# Altium schematic sheet SENSOR-NPC(1210-20).SchDoc(SENSOR-NPC(1210-20))
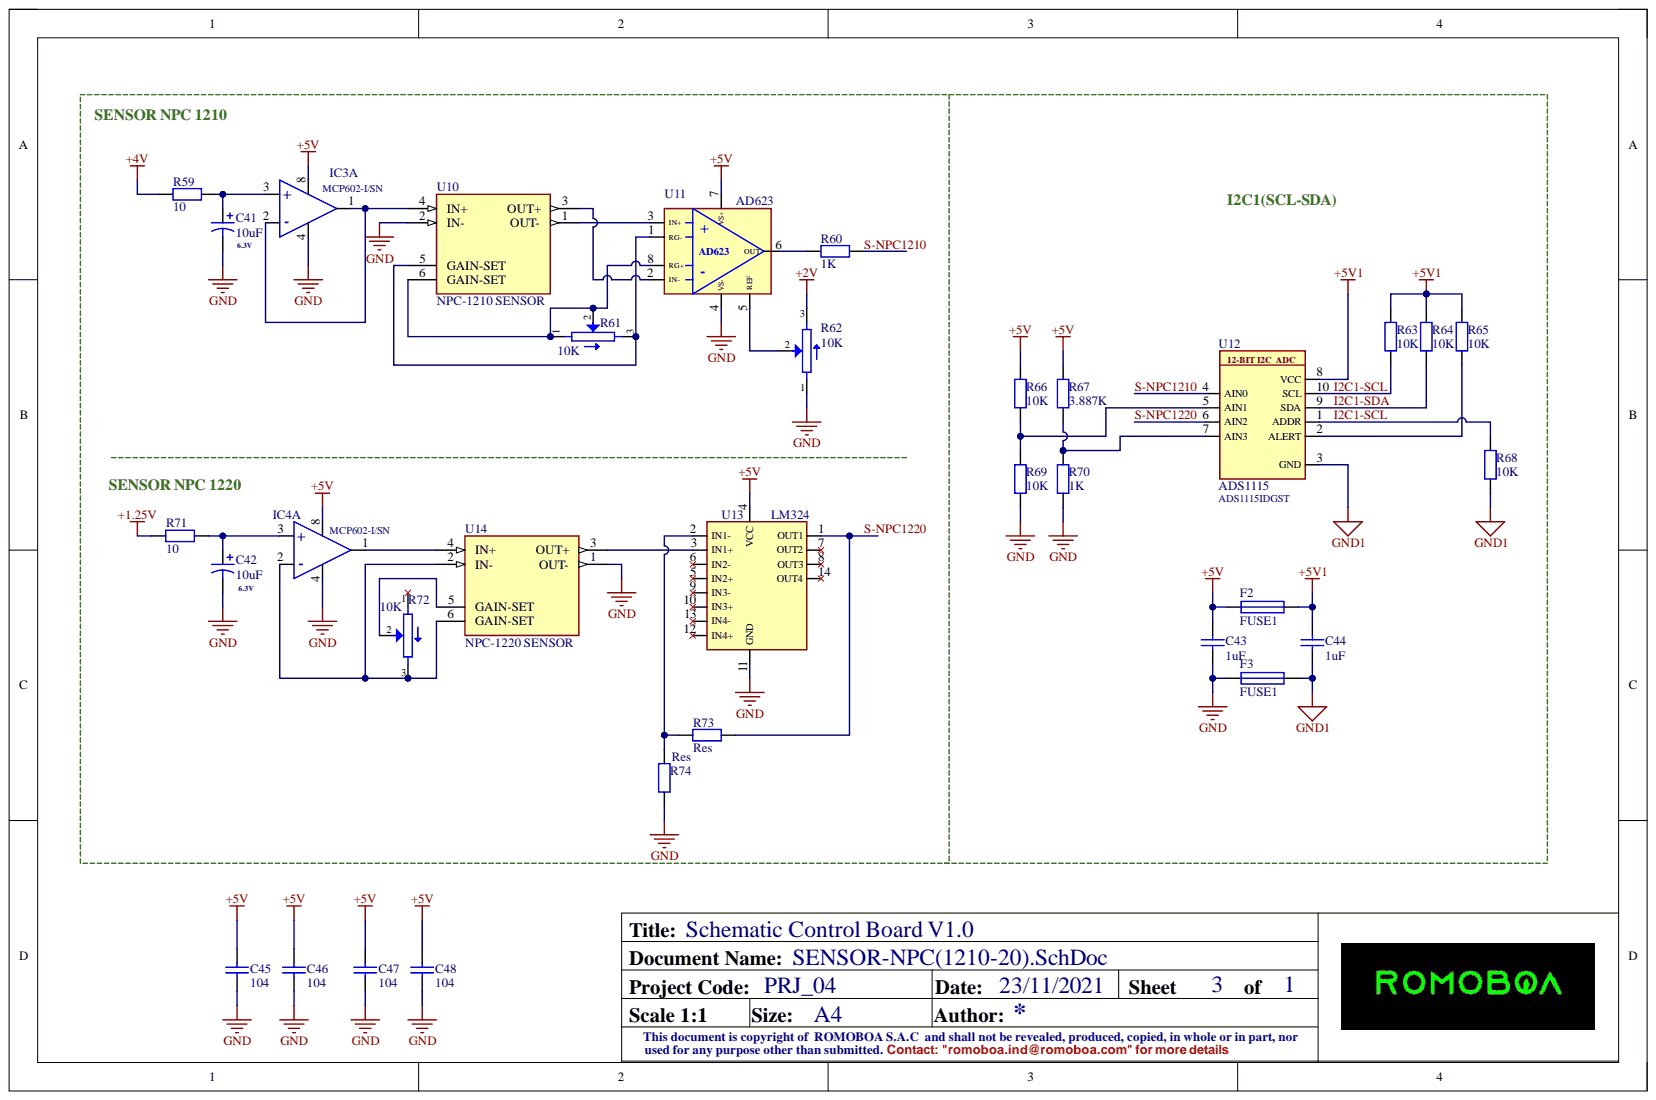
[diagram p0: SENSOR-NPC]

## Parts
C41  10uF  [CAPAE-D5.0x5.4]
C42  10uF  [CAPAE-D5.0x5.4]
C43  1uF  [CAP0805]
C44  1uF  [CAP0805]
C45  104  [CAP0805]
C46  104  [CAP0805]
C47  104  [CAP0805]
C48  104  [CAP0805]
F2  FUSE1  [FUSE: 0805]
F3  FUSE1  [FUSE: 0805]
IC3  Manufacturer Part Number: MCP602-I/SN  [MAX485 :SOIC-8]  (hidden comment: MCP602SN)  (part A)
IC4  Manufacturer Part Number: MCP602-I/SN  [MAX485 :SOIC-8]  (hidden comment: MCP602SN)  (part A)
R59  10  [RES: 0805R]
R60  1K  [RES: 0805R]
R61  10K  [POT: RES: 3296W2]
R62  10K  [POT: RES: 3296W2]
R63  10K  [RES: 0805R]
R64  10K  [RES: 0805R]
R65  10K  [RES: 0805R]
R66  10K  [RES: 0805R]
R67  3.887K  [RES: 0805R]
R68  10K  [RES: 0805R]
R69  10K  [RES: 0805R]
R70  1K  [RES: 0805R]
R71  10  [RES: 0805R]
R72  10K  [POT: RES: 3296W2]
R73  Res  [RES: 0805R]
R74  Res  [RES: 0805R]
U10  NPC-1210 SENSOR  [XDCR_NPC-1210-100G-3-S]
U11  AD623  [MAX485 :SOIC-8]
U12  ADS1115  Interface: I2C  Manufacturer Part Number: ADS1115IDGST  [CH340E: 10-MSOP]
U13  LM324  [SOIC-14]
U14  NPC-1220 SENSOR  [XDCR_NPC-1210-100G-3-S]

## Nets
+1.25V: R71.2
+2V: R62.3
+4V: R59.1
+5V: C43.2 C45.2 C46.2 C47.2 C48.2 F2.1 IC3.8 IC4.8 R66.2 R67.2 U11.7(VS+) U13.4(VCC)
+5V1: C44.2 F2.2 R63.1 R64.1 R65.1 U12.8(VCC)
GND: C41.2 C42.2 C43.1 C45.1 C46.1 C47.1 C48.1 F3.1 IC3.4 IC4.4 R62.1 R69.1 R70.1 R74.2 U10.2(IN-) U11.4(VS-) U13.11(GND) U14.1(OUT-)
GND1: C44.1 F3.2 R68.2 U12.3(GND)
I2C1-SCL: R63.2 R68.1 U12.1(ADDR) U12.10(SCL)
I2C1-SDA: R64.2 U12.9(SDA)
S-NPC1210: R60.2 U12.4(AIN0)
S-NPC1220: R73.1 U12.6(AIN2) U13.1(OUT1)
NetC41_1: C41.1 IC3.3(+) R59.2
NetC42_1: C42.1 IC4.3(+) R71.1
NetIC3_1: IC3.1 IC3.2(-) U10.4(IN+)
NetIC4_1: IC4.1 U14.4(IN+)
NetIC4_2: IC4.2(-) R72.3 U14.2(IN-) U14.6(GAIN-SET)
NetR60_1: R60.1 U11.6(OUT)
NetR61_1: R61.1 R61.2 U10.6(GAIN-SET) U11.8(RG+)
NetR61_3: R61.3 U10.5(GAIN-SET) U11.1(RG-)
NetR62_2: R62.2 U11.5(REF)
NetR65_2: R65.2 U12.2(ALERT)
NetR66_1: R66.1 R69.2 U12.5(AIN1)
NetR67_1: R67.1 R70.2 U12.7(AIN3)
NetR72_2: R72.2 U14.5(GAIN-SET)
NetR73_2: R73.2 R74.1 U13.2(IN1-)
NetU10_1: U10.1(OUT-) U11.3(IN+)
NetU10_3: U10.3(OUT+) U11.2(IN-)
NetU13_3: U13.3(IN1+) U14.3(OUT+)

Not connected: R72 1; U13 5(IN2+) 6(IN2-) 7(OUT2) 8(OUT3) 9(IN3-) 10(IN3+) 12(IN4+) 13(IN4-) 14(OUT4)

## Library data of the parts
C41 C42 = CAP_SMD_P: CAPACITOR SMD POLARIZADO; Component Kind: Standard; Library Name: CAPACITORES.IntLib
C43-C48 = CAP_SMD_NP: CAPACITOR SMD NO POLARIZADO; Component Kind: Standard; Library Name: CAPACITORES.IntLib
F2 F3 = FUSE1: FUSE; Component Kind: Standard; Library Name: FUSE-VARISTOR.IntLib
IC3 IC4 = MCP602SN: MICROCHIP OP AMP, CMOS RRO/P, SMD, SOIC8, 602; Amplifier Type: GENERAL PURPOSE; Bandwidth: 2.8MHz; Common Mode Rejection Ratio: 75dB; Input Bias Current: 1pA; Input Offset Voltage (Vos): 2mV; Input Offset Voltage-Max: 3mV; Max Supply Voltage: 6V; Min Supply Voltage: 2.7V; Nominal Supply Current: 230uA; Number of Amplifiers: 2; Operating Supply Voltage: 5.5V; Output Current: 22mA; Power Supply Rejection Ratio (PSRR): 80dB; Slew Rate: 2.3V/µs; Voltage: 7V; Voltage Gain: 115dB; Case/Package: SOIC; Category: Amplifiers - Op Amps, Buffer, Instrumentation; Component Kind: Standard; ComponentLink1Description: https://datasheet.ciiva.com/26344/651505-da-01-en-ic-opamp-mcp602-i-sn-soic-8n-mcp-26344515.pdf?src-supplier=Conrad; ComponentLink1URL: https://datasheet.ciiva.com/26344/651505-da-01-en-ic-opamp-mcp602-i-sn-soic-8n-mcp-26344515.pdf?src-supplier=Conrad; ComponentLink2Description: https://datasheet.ciiva.com/1793/21314g-1793639.pdf?src-supplier=Digi-Key; ComponentLink2URL: https://datasheet.ciiva.com/1793/21314g-1793639.pdf?src-supplier=Digi-Key; ComponentLink3Description: https://datasheet.ciiva.com/17573/21314g-17573449.pdf?src-supplier=Avnet+Europe; ComponentLink3URL: https://datasheet.ciiva.com/17573/21314g-17573449.pdf?src-supplier=Avnet+Europe; ComponentLink4Description: https://datasheet.ciiva.com/2973/21314g-2973691.pdf?src-supplier=Avnet; ComponentLink4URL: https://datasheet.ciiva.com/2973/21314g-2973691.pdf?src-supplier=Avnet; ComponentLink5Description: https://datasheet.ciiva.com/14151/prmcp601-4-data-e-14151469.pdf?src-supplier=Distrelec; ComponentLink5URL: https://datasheet.ciiva.com/14151/prmcp601-4-data-e-14151469.pdf?src-supplier=Distrelec; ComponentLink6Description: https://datasheet.ciiva.com/8078/77774-8078750.pdf?src-supplier=Element14; ComponentLink6URL: https://datasheet.ciiva.com/8078/77774-8078750.pdf?src-supplier=Element14; Gain Bandwidth Product: 2.8MHz; Height: 1.25mm; Lead Free: Lead Free; Length: 4.9mm; Manufacturer: Microchip; Max Operating Temperature: 85°C; Min Operating Temperature: -40°C; Mount: Surface Mount; Number of Channels: 2; Number of Circuits: 2; Number of Elements: 2; Number of Pins: 8; Package Quantity: 100; Packaging: Rail/Tube; Pricing 1: 1=0.70570, 10=0.53050, 30=0.50000, 100=0.46740, 500=0.45340, 1000=0.44640, 1=0.69340, 10=0.52120, 30=0.49130, 100=0.45920, 500=0.44550, 1000=0.43860, 1=3.51350, 10=2.64130, 30=2.48970, 100=2.32710, 500=2.25740, 1000=2.22260, 1=3.35860, 10=2.52480, 30=2.37990, 100=2.22450, 500=2.15790, 1000=2.12460, 1=0.45160, 10=0.33950, 30=0.32000, 100=0.29910, 500=0.29010, 1000=0.28560, 1=0.39600, 10=0.29770, 30=0.28060, 100=0.26230, 500=0.25440, 1000=0.25050, 1=4.22190, 10=3.17380, 30=2.99160, 100=2.79630, 500=2.71250, 1000=2.67070, 1=57.25270, 10=43.03940, 30=40.56890, 100=37.91970, 500=36.78430, 1000=36.21660, 1=2.21190, 10=1.66280, 30=1.56730, 100=1.46500, 500=1.42110, 1000=1.39920, 1=40.51850, 10=30.45960, 30=28.71120, 100=26.83630, 500=26.03270, 1000=25.63100, 1=4.56540, 10=3.43200, 30=3.23500, 100=3.02370, 500=2.93320, 1000=2.88790, 1=0.72530, 10=0.54520, 30=0.51390, 100=0.48040, 500=0.46600, 1000=0.45880, 1=0.54460, 10=0.40940, 30=0.38590, 100=0.36070, 500=0.34990, 1000=0.34450 (AUD); Radiation Hardening: No; REACH SVHC: No SVHC; RoHS: Yes; RoHS Compliant: Yes; Slew Rate-Nom: 2.3V/µs; Stock 1: 7; Supplier 1: LCSC; Supplier Part Number 1: C29605; Supply Current: 230uA; Technology: CMOS; Unity Gain Bandwidth Product: 2.8MHz; Width: 3.9mm; Library Name: IC-COMUNICACION.IntLib
R59 R60 R63-R71 R73 R74 = Res2-SMD: Component Kind: Standard; Library Name: RESISTOR-POT..IntLib
R61 R62 R72 = POTENCIOMETRO1: RESISTOR-VARIABLE; Component Kind: Standard; Library Name: RESISTOR-POT..IntLib
U10 = NPC-1210 SENSOR: Pressure Sensor, PCB Mount, 10in. Water, 0.36psid, Short Tube, 50mV Output, DIP, Down Through; Manufacturer: Amphenol; Manufacturer Part Number: NPC-1210-10WD-3S; Accuracy: 0.5%; Max Pressure: 5PSI; Output Type: Wheatstone Bridge; Port Style: Barbless; Pressure Type: Differential; Category: Pressure Sensors; Component Kind: Standard; ComponentLink1Description: https://datasheet.ciiva.com/29833/aas-920-303c-novasensor-npc-1210-081214-web-29833703.pdf?src-supplier=Digi-Key; ComponentLink1URL: https://datasheet.ciiva.com/29833/aas-920-303c-novasensor-npc-1210-081214-web-29833703.pdf?src-supplier=Digi-Key; ComponentLink2Description: https://datasheet.ciiva.com/46653/70181318-46653212.pdf?src-supplier=Allied+Electronics; ComponentLink2URL: https://datasheet.ciiva.com/46653/70181318-46653212.pdf?src-supplier=Allied+Electronics; Library Name: MODULOS-CIRCUIT.IntLib; Max Operating Temperature: 125°C; Min Operating Temperature: -40°C; Mount: PCB; Operating Pressure: 0.36PSI; Package Quantity: 1; Pricing 1: 1=55.44000, 10=51.06000, 50=43.76000, 100=42.30000, 1000=42.30000, 10000=42.30000 (USD); RoHS: Yes; RoHS Compliant: Yes; Stock 1: 37; Supplier 1: Mouser; Supplier Part Number 1: 527-NPC-1210-10WD-3S; Termination: PC Pin
U11 = AD623: ANALOG DEVICES - AD623ARZ. - IC, INSTR AMP, 800KHZ, 110DB, 8SOIC; Common Mode Rejection Ratio: 90dB; Input Bias Current: 25nA; Input Offset Voltage (Vos): 25uV; Input Resistance: 2GR; Max Dual Supply Voltage: 6V; Max Power Dissipation: 650mW; Max Supply Current: 550uA; Max Supply Voltage: 12V; Min Dual Supply Voltage: 2.5V; Min Supply Voltage: 2.7V; Number of Amplifiers: 1; Power Supply Rejection Ratio (PSRR): 80dB; Settling Time: 20us; Slew Rate: 0.3V/µs; -3db Bandwidth: 800kHz; Bandwidth: 800kHz; Case/Package: SOIC; Category: Amplifiers - Op Amps, Buffer, Instrumentation; Component Kind: Standard; ComponentLink1Description: https://datasheet.ciiva.com/15128/getdatasheetpartid-115160-15128255.pdf?src-supplier=Verical; ComponentLink1URL: https://datasheet.ciiva.com/15128/getdatasheetpartid-115160-15128255.pdf?src-supplier=Verical; ComponentLink2Description: https://datasheet.ciiva.com/20167/82-1224-20167284.pdf?src-supplier=Rapid+Electronics; ComponentLink2URL: https://datasheet.ciiva.com/20167/82-1224-20167284.pdf?src-supplier=Rapid+Electronics; ComponentLink3Description: https://datasheet.ciiva.com/26850/ad623arz-26850182.pdf?src-supplier=IIIC; ComponentLink3URL: https://datasheet.ciiva.com/26850/ad623arz-26850182.pdf?src-supplier=IIIC; ComponentLink4Description: https://datasheet.ciiva.com/1493/ad623-1493398.pdf?src-supplier=Farnell; ComponentLink4URL: https://datasheet.ciiva.com/1493/ad623-1493398.pdf?src-supplier=Farnell; ComponentLink5Description: https://datasheet.ciiva.com/1486/ad623-1486440.pdf?src-supplier=Element14; ComponentLink5URL: https://datasheet.ciiva.com/1486/ad623-1486440.pdf?src-supplier=Element14; ComponentLink6Description: https://datasheet.ciiva.com/1794/ad623-1794600.pdf?src-supplier=Digi-Key; ComponentLink6URL: https://datasheet.ciiva.com/1794/ad623-1794600.pdf?src-supplier=Digi-Key; ComponentLink7Description: https://datasheet.ciiva.com/1134/82242-1134268.pdf?src-supplier=Element14; ComponentLink7URL: https://datasheet.ciiva.com/1134/82242-1134268.pdf?src-supplier=Element14; ComponentLink8Description: https://datasheet.ciiva.com/25896/ad623-25896590.pdf?src-supplier=Avnet+Europe; ComponentLink8URL: https://datasheet.ciiva.com/25896/ad623-25896590.pdf?src-supplier=Avnet+Europe; ComponentLink9Description: https://datasheet.ciiva.com/14094/saad623-e-14094172.pdf?src-supplier=Distrelec; ComponentLink9URL: https://datasheet.ciiva.com/14094/saad623-e-14094172.pdf?src-supplier=Distrelec; ComponentLink10Description: https://datasheet.ciiva.com/2976/ad623-2976394.pdf?src-supplier=Avnet; ComponentLink10URL: https://datasheet.ciiva.com/2976/ad623-2976394.pdf?src-supplier=Avnet; ComponentLink11Description: https://datasheet.ciiva.com/15375/ad623-15375279.pdf?src-supplier=Mouser; ComponentLink11URL: https://datasheet.ciiva.com/15375/ad623-15375279.pdf?src-supplier=Mouser; ComponentLink12Description: https://datasheet.ciiva.com/22655/82242-22655407.pdf?src-supplier=Element14; ComponentLink12URL: https://datasheet.ciiva.com/22655/82242-22655407.pdf?src-supplier=Element14; Contact Plating: Tin,Matte; Gain Bandwidth Product: 800kHz; Height: 1.5mm; Lead Free: Contains Lead; Length: 5mm; Manufacturer: Analog Devices; Manufacturer Part Number: AD623ARZ; Max Operating Temperature: 85°C; Min Operating Temperature: -40°C; Mount: Surface Mount; Nominal Supply Current: 550uA; Number of Channels: 1; Number of Circuits: 1; Number of Elements: 1; Number of Pins: 8; Operating Supply Current: 550uA; Package Quantity: 98; Packaging: Rail/Tube; Power Dissipation: 650mW; Pricing 1: 1=4.06830, 10=3.54240, 30=3.44430, 100=3.34850, 500=3.30410, 1000=3.25040, 1=3.99720, 10=3.48050, 30=3.38420, 100=3.28990, 500=3.24640, 1000=3.19360, 1=20.25540, 10=17.63740, 30=17.14900, 100=16.67160, 500=16.45090, 1000=16.18320, 1=19.36220, 10=16.85960, 30=16.39280, 100=15.93640, 500=15.72550, 1000=15.46960, 1=2.60320, 10=2.26680, 30=2.20400, 100=2.14260, 500=2.11430, 1000=2.07990, 1=2.28270, 10=1.98760, 30=1.93260, 100=1.87880, 500=1.85390, 1000=1.82380, 1=24.33930, 10=21.19340, 30=20.60650, 100=20.03280, 500=19.76770, 1000=19.44600, 1=330.06000, 10=287.39910, 30=279.44090, 100=271.66140, 500=268.06600, 1000=263.70320, 1=12.75150, 10=11.10330, 30=10.79590, 100=10.49530, 500=10.35640, 1000=10.18790, 1=233.58780, 10=203.39610, 30=197.76400, 100=192.25840, 500=189.71390, 1000=186.62620, 1=26.31920, 10=22.91740, 30=22.28280, 100=21.66240, 500=21.37570, 1000=21.02780, 1=4.18130, 10=3.64090, 30=3.54010, 100=3.44150, 500=3.39600, 1000=3.34070, 1=3.13960, 10=2.73380, 30=2.65810, 100=2.58410, 500=2.54990, 1000=2.50840 (AUD); Radiation Hardening: No; REACH SVHC: No SVHC; RoHS: Yes; RoHS Compliant: Yes; Stock 1: 1033; Supplier 1: LCSC; Supplier Part Number 1: C578342; Weight: 0.019048oz; Width: 4mm; Library Name: IC-COMUNICACION.IntLib
U12 = ADS1115: ANALOG TO DIGITAL CONVERTER ADC, 16 BIT, 860SPS, MSOP-10; Manufacturer: Texas Instruments; Conversion Rate: 860S/s; Integral Nonlinearity (INL): 1LSB; Max Power Dissipation: 500uW; Max Supply Voltage: 5.5V; Min Supply Voltage: 2V; Nominal Supply Current: 150uA; Number of A/D Converters: 1; Number of Bits: 16; Number of Channels: 4; Operating Supply Voltage: 3.3V; Polarity: Bipolar; Power Dissipation: 900uW; Resolution: 16b; Supply Type: Single; Case/Package: VSSOP; Category: Analog to Digital Converters (ADCs); Component Kind: Standard; ComponentLink1Description: https://datasheet.ciiva.com/46384/1789034-46384549.pdf?src-supplier=Element14; ComponentLink1URL: https://datasheet.ciiva.com/46384/1789034-46384549.pdf?src-supplier=Element14; ComponentLink2Description: https://datasheet.ciiva.com/15148/getdatasheetpartid-202210-15148135.pdf?src-supplier=Verical; ComponentLink2URL: https://datasheet.ciiva.com/15148/getdatasheetpartid-202210-15148135.pdf?src-supplier=Verical; ComponentLink3Description: https://datasheet.ciiva.com/1261/ads1113-1261331.pdf?src-supplier=Digi-Key; ComponentLink3URL: https://datasheet.ciiva.com/1261/ads1113-1261331.pdf?src-supplier=Digi-Key; ComponentLink4Description: https://datasheet.ciiva.com/1261/ads1113-1261332.pdf?src-supplier=Digi-Key; ComponentLink4URL: https://datasheet.ciiva.com/1261/ads1113-1261332.pdf?src-supplier=Digi-Key; ComponentLink5Description: https://datasheet.ciiva.com/1261/ads1113-1261333.pdf?src-supplier=Digi-Key; ComponentLink5URL: https://datasheet.ciiva.com/1261/ads1113-1261333.pdf?src-supplier=Digi-Key; ComponentLink6Description: https://datasheet.ciiva.com/25993/sbas444b-25993209.pdf?src-supplier=Avnet+Europe; ComponentLink6URL: https://datasheet.ciiva.com/25993/sbas444b-25993209.pdf?src-supplier=Avnet+Europe; ComponentLink7Description: https://datasheet.ciiva.com/1704/1789034-1704282.pdf?src-supplier=Element14; ComponentLink7URL: https://datasheet.ciiva.com/1704/1789034-1704282.pdf?src-supplier=Element14; ComponentLink8Description: https://datasheet.ciiva.com/3039/sbas444b-3039517.pdf?src-supplier=Avnet; ComponentLink8URL: https://datasheet.ciiva.com/3039/sbas444b-3039517.pdf?src-supplier=Avnet; ComponentLink9Description: https://datasheet.ciiva.com/3037/sbas444b-3037903.pdf?src-supplier=Avnet; ComponentLink9URL: https://datasheet.ciiva.com/3037/sbas444b-3037903.pdf?src-supplier=Avnet; ComponentLink10Description: https://datasheet.ciiva.com/22696/1789034-22696415.pdf?src-supplier=Element14; ComponentLink10URL: https://datasheet.ciiva.com/22696/1789034-22696415.pdf?src-supplier=Element14; Contact Plating: Gold; Height: 1.02mm; Lead Free: Lead Free; Length: 3mm; Max Operating Temperature: 125°C; Max Supply Voltage (DC): 5.5V; Min Operating Temperature: -40°C; Min Supply Voltage (DC): 2V; Number of Converters: 1; Number of Elements: 1; Number of Inputs: 4; Number of Pins: 10; Package Quantity: 250; Packaging: Tape and Reel; Pricing 1: 1=6.10000, 10=5.48000, 50=5.18000, 100=4.26000, 1000=3.06000, 10000=2.95000 (USD); Radiation Hardening: No; REACH SVHC: No SVHC; RoHS: Yes; RoHS Compliant: Yes; Sampling Rate: 860S/s; Stock 1: 4127; Supplier 1: Mouser; Supplier Part Number 1: 595-ADS1115IDGST; Termination: SMD/SMT; Width: 3mm; Library Name: IC-COMUNICACION.IntLib
U13 = LM324: LM Series 0.6 V/us 32 V SMT Single Supply Quad Operational Amplifier - SOIC-14; Manufacturer: ON Semiconductor; Manufacturer Part Number: LM324DR2G; Amplifier Type: GENERAL PURPOSE; Bandwidth: 1MHz; Common Mode Rejection Ratio: 65dB; Dual Supply Voltage: 9V; Input Bias Current: 250nA; Input Offset Voltage (Vos): 7mV; Input Offset Voltage-Max: 3mV; Max Dual Supply Voltage: 16V; Max Supply Voltage: 32V; Min Dual Supply Voltage: 1.5V; Min Supply Voltage: 3V; Nominal Supply Current: 1.2mA; Number of Amplifiers: 4; Operating Supply Current: 1.4mA; Output Current: 40mA; Slew Rate: 0.6V/µs; Voltage Gain: 100dB; Case/Package: SOIC; Category: Amplifiers - Op Amps, Buffer, Instrumentation; Component Kind: Standard; ComponentLink1Description: https://datasheet.ciiva.com/17093/lm324dr2g-17093592.pdf?src-supplier=Allied+Electronics; ComponentLink1URL: https://datasheet.ciiva.com/17093/lm324dr2g-17093592.pdf?src-supplier=Allied+Electronics; ComponentLink2Description: https://datasheet.ciiva.com/15129/getdatasheetpartid-53739-15129983.pdf?src-supplier=Verical; ComponentLink2URL: https://datasheet.ciiva.com/15129/getdatasheetpartid-53739-15129983.pdf?src-supplier=Verical; ComponentLink3Description: https://datasheet.ciiva.com/2973/lm324-d-2973349.pdf?src-supplier=Avnet; ComponentLink3URL: https://datasheet.ciiva.com/2973/lm324-d-2973349.pdf?src-supplier=Avnet; ComponentLink4Description: https://datasheet.ciiva.com/26222/lm324-d-26222822.pdf?src-supplier=Avnet+Europe; ComponentLink4URL: https://datasheet.ciiva.com/26222/lm324-d-26222822.pdf?src-supplier=Avnet+Europe; ComponentLink5Description: https://datasheet.ciiva.com/16546/lm324-d-16546057.pdf?src-supplier=Avnet+Asia; ComponentLink5URL: https://datasheet.ciiva.com/16546/lm324-d-16546057.pdf?src-supplier=Avnet+Asia; ComponentLink6Description: https://datasheet.ciiva.com/5787/81842-5787674.pdf?src-supplier=Farnell; ComponentLink6URL: https://datasheet.ciiva.com/5787/81842-5787674.pdf?src-supplier=Farnell; ComponentLink7Description: https://datasheet.ciiva.com/1795/lm324-d-1795595.pdf?src-supplier=Digi-Key; ComponentLink7URL: https://datasheet.ciiva.com/1795/lm324-d-1795595.pdf?src-supplier=Digi-Key; ComponentLink8Description: https://datasheet.ciiva.com/1795/lm324-d-1795596.pdf?src-supplier=Digi-Key; ComponentLink8URL: https://datasheet.ciiva.com/1795/lm324-d-1795596.pdf?src-supplier=Digi-Key; ComponentLink9Description: https://datasheet.ciiva.com/1795/lm324-d-1795597.pdf?src-supplier=Digi-Key; ComponentLink9URL: https://datasheet.ciiva.com/1795/lm324-d-1795597.pdf?src-supplier=Digi-Key; ComponentLink10Description: https://datasheet.ciiva.com/2975/lm324-d-2975022.pdf?src-supplier=Avnet; ComponentLink10URL: https://datasheet.ciiva.com/2975/lm324-d-2975022.pdf?src-supplier=Avnet; Gain Bandwidth Product: 1MHz; Halogen Free: Halogen Free; Height: 1.5mm; Lead Free: Lead Free; Length: 8.75mm; Max Operating Temperature: 70°C; Min Operating Temperature: 0°C; Number of Channels: 4; Number of Circuits: 4; Number of Elements: 4; Number of Pins: 14; Operating Supply Voltage: 16V; Output Current per Channel: 40mA; Package Quantity: 2500; Packaging: Tape and Reel; Power Supply Rejection Ratio (PSRR): 65dB; Pricing 1: 5=0.16380, 50=0.12530, 150=0.11820, 500=0.11120, 2500=0.10790, 5000=0.10640, 5=0.16090, 50=0.12310, 150=0.11610, 500=0.10920, 2500=0.10610, 5000=0.10450, 5=0.81550, 50=0.62390, 150=0.58840, 500=0.55350, 2500=0.53740, 5000=0.52970, 5=0.77950, 50=0.59640, 150=0.56240, 500=0.52910, 2500=0.51370, 5000=0.50630, 5=0.10480, 50=0.08020, 150=0.07560, 500=0.07110, 2500=0.06910, 5000=0.06810, 5=0.09190, 50=0.07030, 150=0.06630, 500=0.06240, 2500=0.06060, 5000=0.05970, 5=0.97990, 50=0.74970, 150=0.70700, 500=0.66520, 2500=0.64580, 5000=0.63650, 5=13.28820, 50=10.16590, 150=9.58770, 500=9.02000, 2500=8.75720, 5000=8.63100, 5=0.51340, 50=0.39270, 150=0.37040, 500=0.34850, 2500=0.33830, 5000=0.33340, 5=9.40420, 50=7.19450, 150=6.78530, 500=6.38360, 2500=6.19760, 5000=6.10830, 5=1.05960, 50=0.81060, 150=0.76450, 500=0.71930, 2500=0.69830, 5000=0.68820, 5=0.16830, 50=0.12880, 150=0.12150, 500=0.11430, 2500=0.11090, 5000=0.10930, 5=0.12640, 50=0.09670, 150=0.09120, 500=0.08580, 2500=0.08330, 5000=0.08210 (AUD); Radiation Hardening: No; REACH SVHC: No SVHC; RoHS: Yes; RoHS Compliant: Yes; Series: -; Slew Rate-Nom: 0.6V/µs; Stock 1: 19425; Supplier 1: LCSC; Supplier Part Number 1: C63820; Supply Current: 1.2mA; Technology: BIPOLAR; Width: 4mm; Library Name: IC-COMUNICACION.IntLib
U14 = NPC-1220 SENSOR: SENSOR PRES 100PSIA 0-50MV DIP; Manufacturer: Amphenol; Manufacturer Part Number: NPC-1220-100A-3-S; Accuracy: 0.1%; Operating Pressure: 100PSI; Output Type: Wheatstone Bridge; Port Style: Barbless; Pressure Type: Absolute; Category: Pressure Sensors; Component Kind: Standard; ComponentLink1Description: https://datasheet.ciiva.com/29833/aas-920-276a-novasensor+npc-1220-042914-web-29833720.pdf?src-supplier=Digi-Key; ComponentLink1URL: https://datasheet.ciiva.com/29833/aas-920-276a-novasensor+npc-1220-042914-web-29833720.pdf?src-supplier=Digi-Key; ComponentLink2Description: https://datasheet.ciiva.com/46653/70237975-46653192.pdf?src-supplier=Allied+Electronics; ComponentLink2URL: https://datasheet.ciiva.com/46653/70237975-46653192.pdf?src-supplier=Allied+Electronics; Library Name: MODULOS-CIRCUIT.IntLib; Max Operating Temperature: 125°C; Min Operating Temperature: -40°C; Mount: PCB; Package Quantity: 1; Pricing 1: 1=46.27000, 10=41.87000, 50=34.14000, 100=33.04000, 1000=31.94000, 10000=31.94000 (USD); RoHS: Yes; Stock 1: 97; Supplier 1: Mouser; Supplier Part Number 1: 527-NPC-1220-100A-3S; Termination: PCB
